# Altium schematic sheet PreHeater_Control.SchDoc
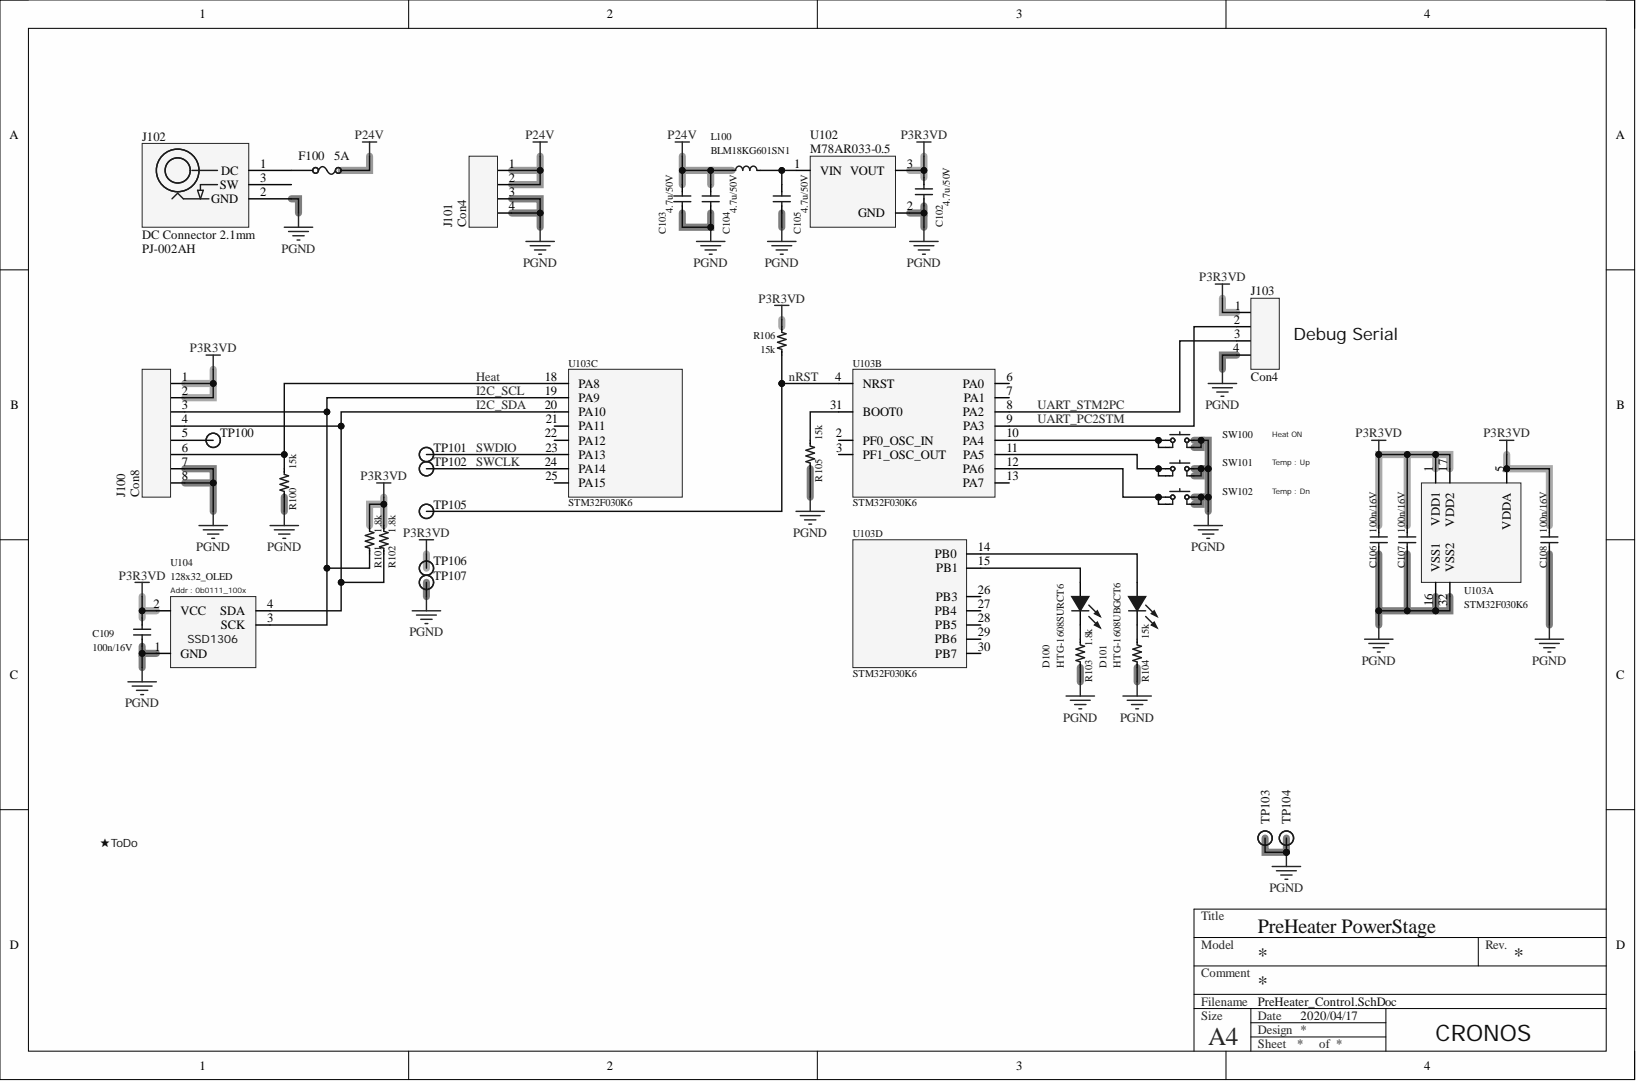
[diagram p0: PreHeater_Control.SchDoc]

## Parts
C102  4.7u/50V  [SC2-3.20X1.60A]
C103  4.7u/50V  [SC2-3.20X1.60A]
C104  4.7u/50V  [SC2-3.20X1.60A]
C105  4.7u/50V  [SC2-3.20X1.60A]
C106  100n/16V  [SC2-1.00X0.50A]
C107  100n/16V  [SC2-1.00X0.50A]
C108  100n/16V  [SC2-1.00X0.50A]
C109  100n/16V  [SC2-1.00X0.50A]
D100  HTG-1608SURCT6  [SOD-523F_CAPM3216X18N]
D101  HTG-1608UBGCT6  [SOD-523F_CAPM3216X18N]
F100  5A  [SR2-3.20X1.60A]
J100  Con8  [SM08B-SRSS-TB]
J101  Con4  [S4B-PH-SM4-TB(LF)(SN)]
J102  DC Connector 2.1mm  value PJ-002AH  Commodity: Connector  Manufacturer Part Number: PJ-002AH  [Connector_PJ-002AH]
J103  Con4  [Pinheader_2.54mm_1x4]
L100  BLM18KG601SN1  [SL2-1.60X0.80A]
R100  15k  [SR2-1.00X0.50A]
R101  1.8k  [SR2-1.00X0.50A]
R102  1.8k  [SR2-1.00X0.50A]
R103  1.8k  [SR2-1.00X0.50A]
R104  15k  [SR2-1.00X0.50A]
R105  15k  [SR2-1.00X0.50A]
R106  15k  [SR2-1.00X0.50A]
SW100  1825910  [1825910]  (value not drawn)
SW101  1825910  [1825910]  (value not drawn)
SW102  1825910  [1825910]  (value not drawn)
TP100  TP  [TP_1.27]
TP101  TP  [TP_1.27]
TP102  TP  [TP_1.27]
TP103  TP  [TP_1.27]
TP104  TP  [TP_1.27]
TP105  TP  [TP_1.27]
TP106  TP  [TP_1.27]
TP107  TP  [TP_1.27]
U102  M78AR033-0.5  [MINMAX_M78A]
U103  STM32F030K6  [LQFP32_e=0.8]  (parts A,B,C,D)
U104  128x32_OLED  [128x32_OLED]

## Nets
P3R3VD: C102.2 C106.1 C107.1 C108.1 C109.1 J100.1 J100.2 J103.1 R101.1 R102.1 R106.1 TP106.1 U102.3 U103.1(VDD1) U103.5(VDDA) U103.17(VDD2) U104.2(VCC)
P24V: C103.2 C104.2 F100.2 J101.1 J101.2 L100.1
PGND: C102.1 C103.1 C104.1 C105.1 C106.2 C107.2 C108.2 C109.2 J100.7 J100.8 J101.3 J101.4 J102.2 J103.4 R100.2 R103.1 R104.1 R105.2 SW100.3 SW100.4 SW101.3 SW101.4 SW102.3 SW102.4 TP103.1 TP104.1 TP107.1 U102.2 U103.16(VSS1) U103.32(VSS2) U104.1(GND)
Heat: J100.6 R100.1 U103.18(PA8)
I2C_SCL: J100.3 R101.2 U103.19(PA9) U104.3(SCK)
I2C_SDA: J100.4 R102.2 U103.20(PA10) U104.4(SDA)
nRST: R106.2 TP105.1 U103.4(NRST)
SWCLK: TP102.1 U103.24(PA14)
SWDIO: TP101.1 U103.23(PA13)
UART_PC2STM: J103.2 U103.9(PA3)
UART_STM2PC: J103.3 U103.8(PA2)
NetC105_2: C105.2 L100.2 U102.1
NetD100_A: D100.A U103.15(PB1)
NetD100_C: D100.C R103.2
NetD101_A: D101.A U103.14(PB0)
NetD101_C: D101.C R104.2
NetF100_1: F100.1 J102.1
NetJ100_5: J100.5 TP100.1
NetR105_1: R105.1 U103.31(BOOT0)
NetSW100_1: SW100.1 SW100.2 U103.10(PA4)
NetSW101_1: SW101.1 SW101.2 U103.11(PA5)
NetSW102_1: SW102.1 SW102.2 U103.12(PA6)

Not connected: J102 3; U103 2(PF0_OSC_IN) 3(PF1_OSC_OUT) 6(PA0) 7(PA1) 13(PA7) 21(PA11) 22(PA12) 25(PA15) 26(PB3) 27(PB4) 28(PB5) 29(PB6) 30(PB7)

## Library data of the parts
C102-C105 = C: 4.7u/50V, X7R, 3216Size; Manufacturer: Murata; Manufacturer Part Number: GRM31CR71H475KA12L; Commodity: MLCC; Replace: OK; Component Kind: Standard; Footprint(imperial): 1206; Footprint(metric): 3216; Value: 4.7u/50V; Library Name: I_Lib_cronos_NewLayer.IntLib
C106-C109 = C: Capacitor (non-polar) 2pin; Manufacturer: TDK; Manufacturer Part Number: C1005X5R1C104KT000N; Commodity: MLCC; Replace: OK; Component Kind: Standard; Description 1: Capacitor (non-polar); FirstPublished: 2012/10/14; Footprint(imperial): 0402; Footprint(metric): 1005; Value: 100n/16V; Library Name: I_Lib_cronos_NewLayer.IntLib
D100 D101 = Diode_LED: Manufacturer: HTGLight; Commodity: LED; Replace: OK; Component Kind: Standard; Footprint(imperial): 0603; Footprint(metric): 1608
  D100: Manufacturer Part Number: HTG-1608SURCT6; Value: HTG-1608SURCT6; Library Name: I_Lib_cronos.IntLib
  D101: Manufacturer Part Number: HTG-1608UBGCT6; Value: HTG-1608UBGCT6; Library Name: I_Lib_cronos_NewLayer.IntLib
F100 = Fuse: 5A, 32V, 3216Size; Manufacturer: Shenzhen lanson Elec; Manufacturer Part Number: 12H1500D; Replace: NG; Commodity: Fuse; Component Kind: Standard; Value: 5A; Library Name: I_Lib_cronos_NewLayer.IntLib
J100 = Con8: SH, Holiz, 8pin, SMD; Manufacturer: JST; Commodity: Connector; Replace: NG; Component Kind: Standard; Manufacturer Part Number: SM08B-SRSS-TB; Value: Con8; Library Name: I_Lib_cronos_NewLayer.IntLib
J101 = Con4: PH, 4pin, SMT, R/A; Manufacturer: JST; Commodity: Connector; Replace: NG; Component Kind: Standard; Manufacturer Part Number: S4B-PH-SM4-TB(LF)(SN); Value: Con4; Library Name: I_Lib_cronos_NewLayer.IntLib
J102 = Connector - DC_PJ-002AH: Connector - DC 12V; Manufacturer: CUI Inc.; Replace: NG; Component Kind: Standard; Library Name: I_Lib_cronos_NewLayer.IntLib
J103 = Con4: Commodity: Connector; Replace: NG; Component Kind: Standard; Value: Con4; Library Name: I_Lib_cronos_NewLayer.IntLib
L100 = L: 600ohm/1.3A/150mohm; Manufacturer: Murata; Commodity: RFC; Replace: OK; Component Kind: Standard; FirstPublished: 2012/10/14; Footprint(imperial): '0603; Footprint(metric): 1608; Manufacturer Part Number: BLM18KG601SN1; Value: 600ohm/1.3A/150mohm; Library Name: I_Lib_cronos_NewLayer.IntLib
R100 R104-R106 = R: Rsisiter 2pin; Manufacturer: YAGEO; Manufacturer Part Number: RC0402FR-0715KL; Commodity: Resistor; Replace: OK; Component Kind: Standard; Description 1: Resistor; FirstPublished: 2012/10/14; Footprint(imperial): 0402; Footprint(metric): 1005; Value: 15k; Library Name: I_Lib_cronos_NewLayer.IntLib
R101-R103 = R: Rsisiter 2pin; Manufacturer: Yageo; Manufacturer Part Number: RC0402FR-071K8L; Commodity: Resistor; Replace: OK; Component Kind: Standard; Description 1: Resistor; FirstPublished: 2012/10/14; Footprint(imperial): 0402; Footprint(metric): 1005; Value: 1.8k; Library Name: I_Lib_cronos.IntLib
SW100-SW102 = TactSW: TE SPST TactSW; Manufacturer: TE Connectivity; Manufacturer Part Number: 3-1825910-9; Value: 3-1825910-9; Component Kind: Standard; Library Name: I_Lib_cronos_NewLayer.IntLib
TP100-TP107 = TP: Mounting: NM; Component Kind: Standard; Library Name: I_Lib_cronos_NewLayer.IntLib
U102 = M78AR033-0.5: VIN:4.75~32V, Vout:3.3V/0.5A; Manufacturer: MINMAX; Commodity: SMPS; Replace: OK; Component Kind: Standard; Manufacturer Part Number: M78AR033-0.5; Value: M78AR033-0.5; Library Name: I_Lib_cronos_NewLayer.IntLib
U103 = STM32F030K6: CM0, Flash32k, SRAM4k, GPIO26; Manufacturer: ST Micro; Commodity: MCU; Replace: NG; Component Kind: Standard; Manufacturer Part Number: STM32F030K6; Value: STM32F030K6; Library Name: SchLib_cronos.SchLib
U104 = 128x32_OLED: 128x32 OLED I2C SSD1306; Manufacturer: Aliexpress; Replace: OK; Commodity: OLED; Component Kind: Standard; Manufacturer Part Number: 128x32_OLED; Value: 128x32_OLED; Library Name: I_Lib_cronos_NewLayer.IntLib
